# Altium schematic sheet 2403_HDD_ROM_Emulator.SchDoc
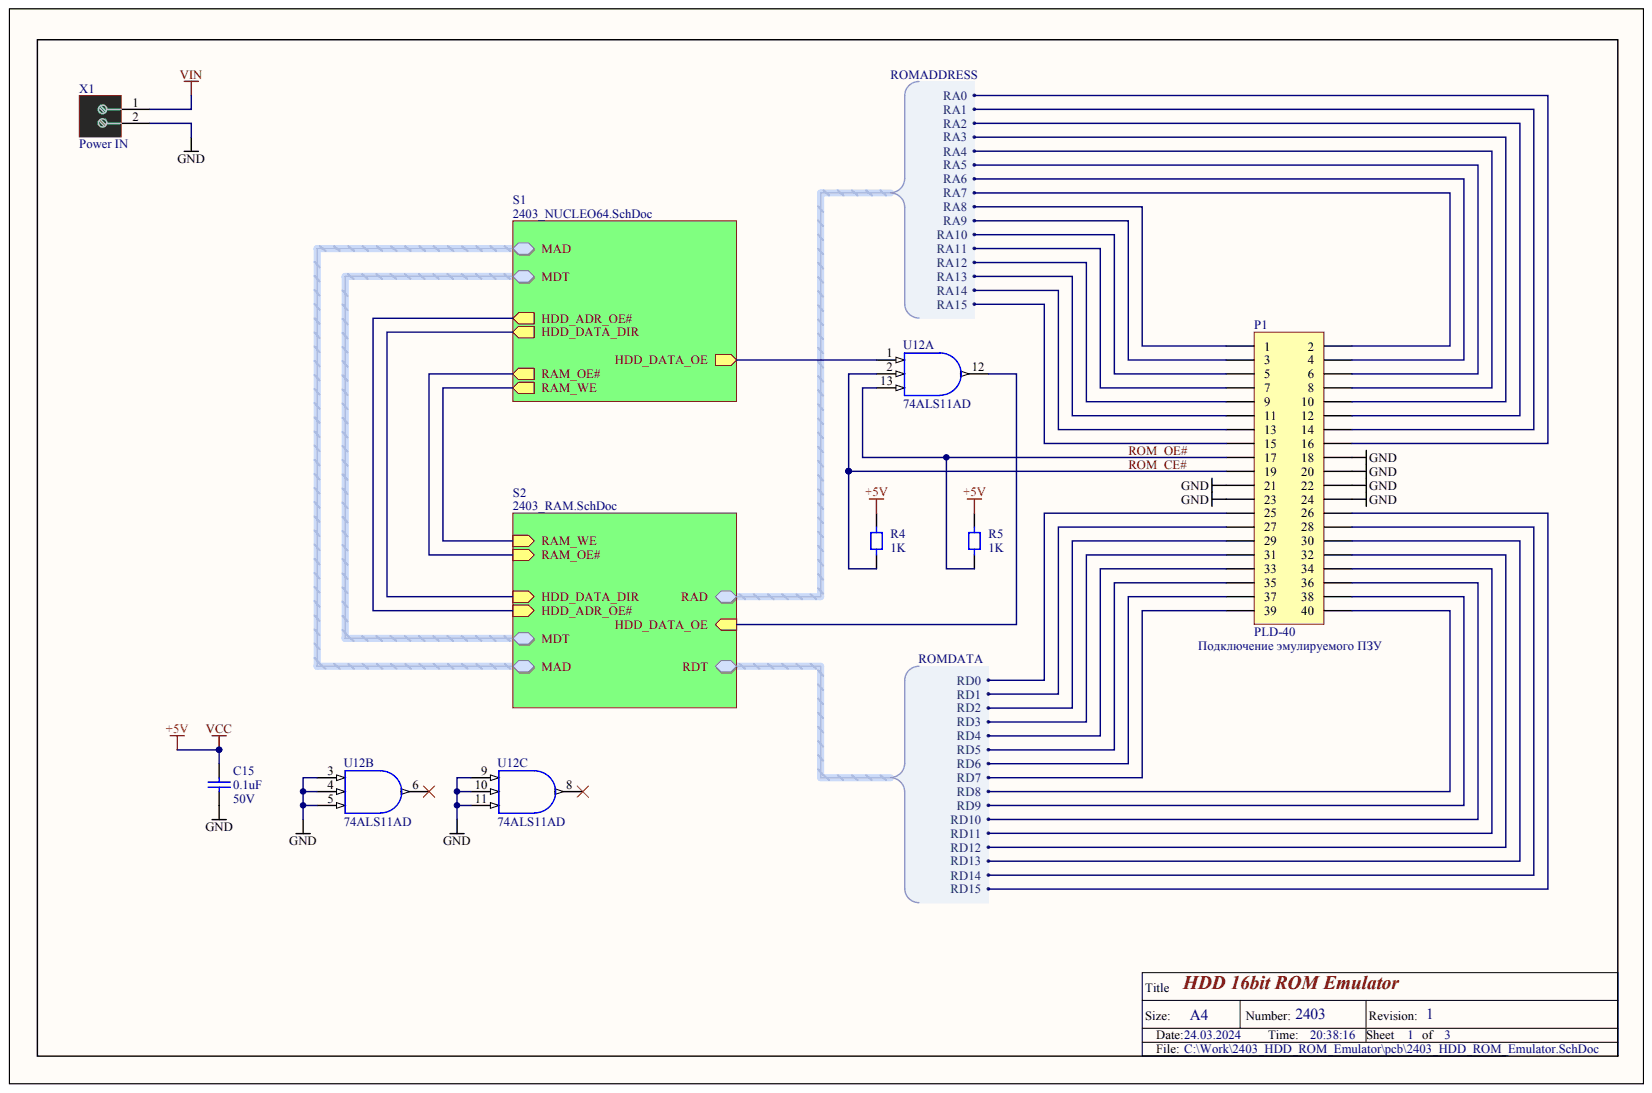
[diagram p0: 2403_HDD_ROM_Emulator.SchDoc]

## Parts
C15  Rated Voltage: 50V  (hidden comment: 0.1uF)
P1  PLD-40
R4  1K
R5  1K
U12  74ALS11AD  (parts A,B,C)
X1  ConnectionName: Power IN  (hidden comment: DG306-5.0 2)

## Nets
+5V: C15.2 R4.2 R5.2 U12.14
GND: C15.1 P1.18 P1.20 P1.21 P1.22 P1.23 P1.24 U12.3 U12.4 U12.5 U12.7 U12.9 U12.10 U12.11 X1.2
VIN: X1.1
ROM_CE#: P1.19 R4.1 U12.2
ROM_OE#: P1.17 R5.1 U12.13
NetP1_1: P1.1
NetP1_2: P1.2
NetP1_3: P1.3
NetP1_4: P1.4
NetP1_5: P1.5
NetP1_6: P1.6
NetP1_7: P1.7
NetP1_8: P1.8
NetP1_9: P1.9
NetP1_10: P1.10
NetP1_11: P1.11
NetP1_12: P1.12
NetP1_13: P1.13
NetP1_14: P1.14
NetP1_15: P1.15
NetP1_16: P1.16
NetP1_25: P1.25
NetP1_26: P1.26
NetP1_27: P1.27
NetP1_28: P1.28
NetP1_29: P1.29
NetP1_30: P1.30
NetP1_31: P1.31
NetP1_32: P1.32
NetP1_33: P1.33
NetP1_34: P1.34
NetP1_35: P1.35
NetP1_36: P1.36
NetP1_37: P1.37
NetP1_38: P1.38
NetP1_39: P1.39
NetP1_40: P1.40
NetU12_1: U12.1
NetU12_6: U12.6
NetU12_8: U12.8
NetU12_12: U12.12
HDD_ADR_OE#:
HDD_DATA_DIR:
MAD.MA0:
MAD.MA1:
MAD.MA2:
MAD.MA3:
MAD.MA4:
MAD.MA5:
MAD.MA6:
MAD.MA7:
MAD.MA8:
MAD.MA9:
MAD.MA10:
MAD.MA11:
MAD.MA12:
MAD.MA13:
MAD.MA14:
MAD.MA15:
MDT.MD0:
MDT.MD1:
MDT.MD2:
MDT.MD3:
MDT.MD4:
MDT.MD5:
MDT.MD6:
MDT.MD7:
MDT.MD8:
MDT.MD9:
MDT.MD10:
MDT.MD11:
MDT.MD12:
MDT.MD13:
MDT.MD14:
MDT.MD15:
RAM_OE#:
RAM_WE:

## Library data of the parts
C15 = (no symbol name): Suppler: chips; VALUE: 0.1uF; PackageReference: 0805; Price: 1; Publisher: SY
P1 = (no symbol name): Suppler: PP; Price: ?; Source: 2403; Publisher: SY
R4 R5 = (no symbol name): Tolerance: 5%; Power: 0.1W; VALUE: 1K; Price: *; Suppler: *; Package: 0805; Publisher: SY
U12 = (no symbol name): Suppler: RR; Price: ?; Publisher: SY; Source: 2403_HDD_ROM_Emulator
X1 = (no symbol name): Suppler: promelec; Price: 5?; Publisher: SY
